# Altium schematic sheet [01] BP_LCD_Panel_v1.SchDoc("[01] BP_LCD_Panel_v1")
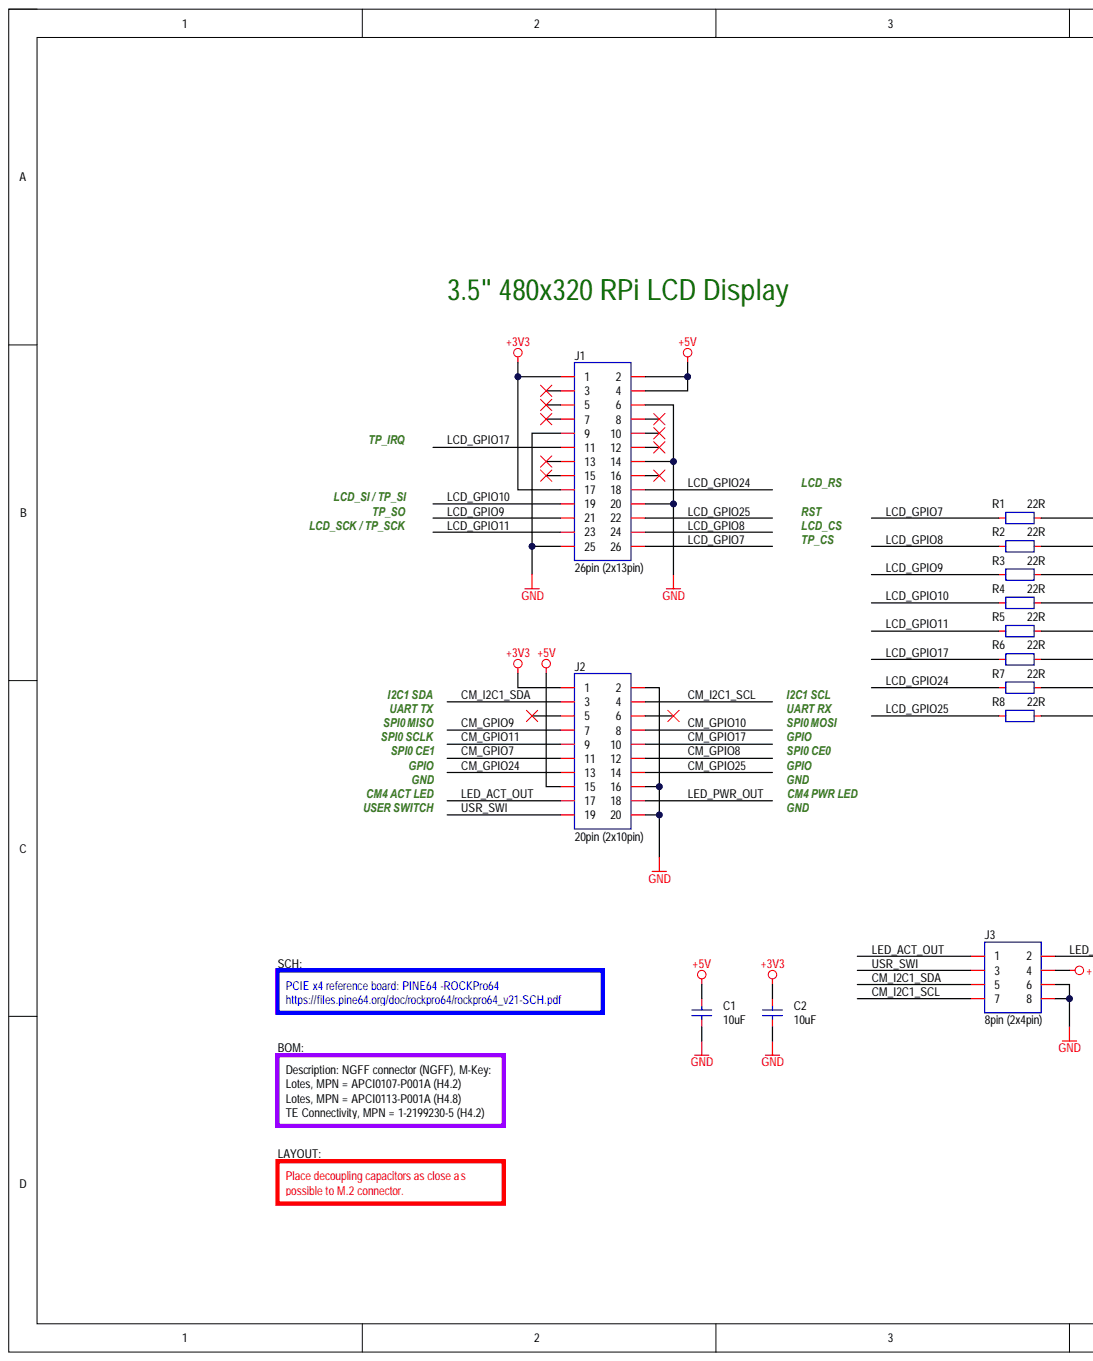
[diagram p0: [01] BP_LCD_Panel_v1.SchDoc, left]
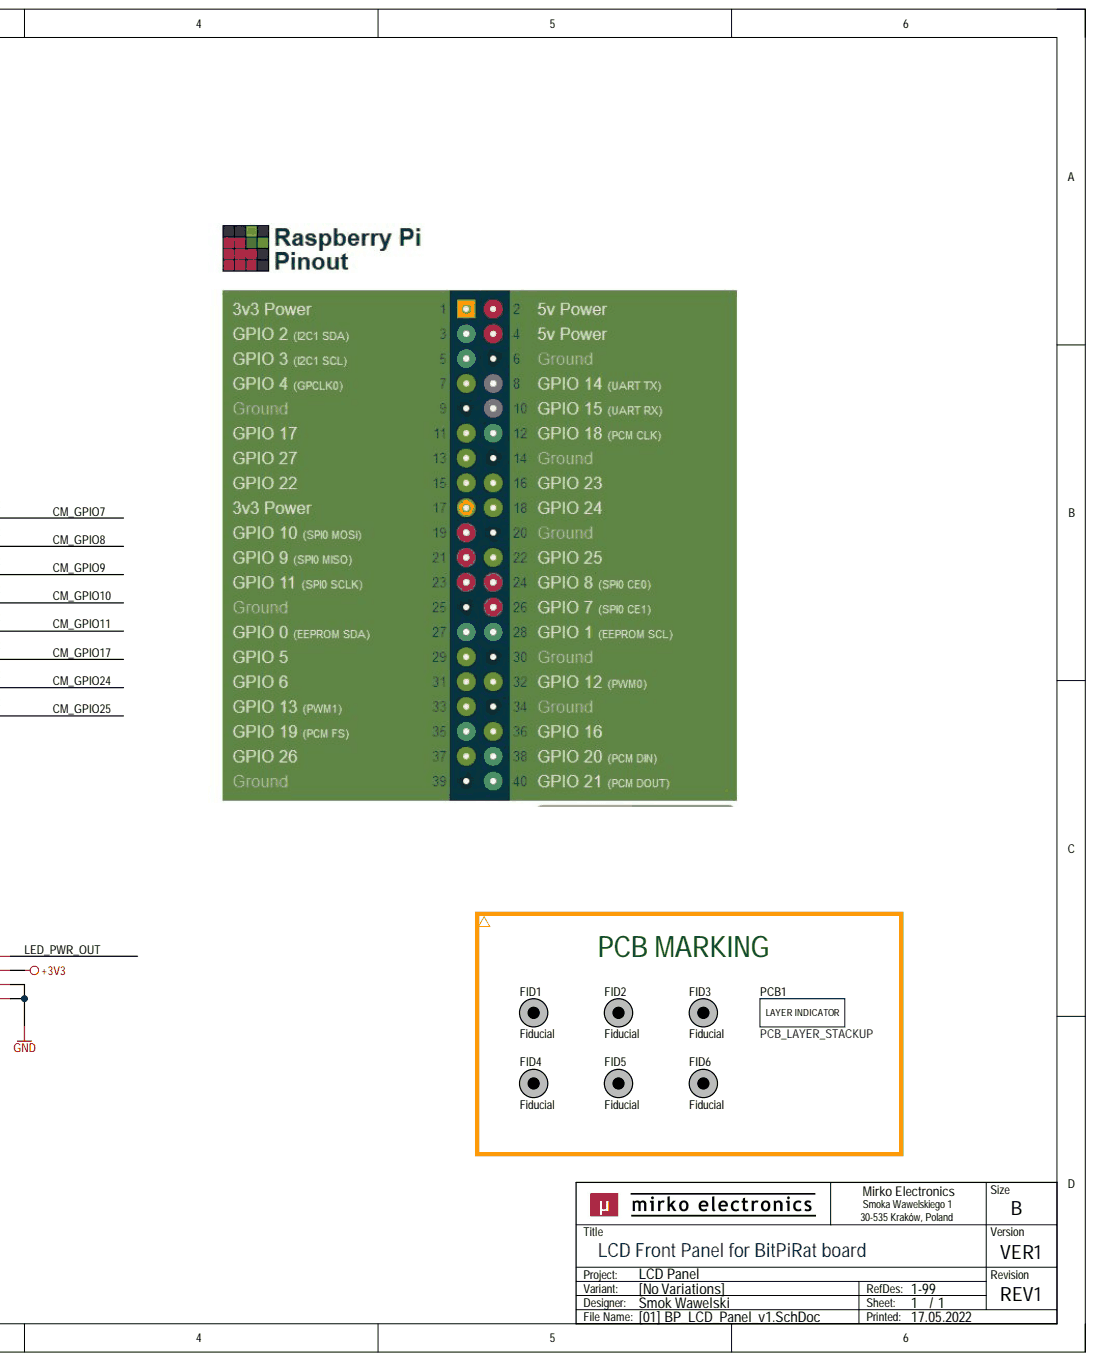
[diagram p1: [01] BP_LCD_Panel_v1.SchDoc, right]

## Parts
C1  10uF  [CAP_0603_R]
C2  10uF  [CAP_0603_R]
FID1  Fiducial  [PCB_FID_RND_100x300]  (no BOM)
FID2  Fiducial  [PCB_FID_RND_100x300]  (no BOM)
FID3  Fiducial  [PCB_FID_RND_100x300]  (no BOM)
FID4  Fiducial  [PCB_FID_RND_100x300]  (no BOM)
FID5  Fiducial  [PCB_FID_RND_100x300]  (no BOM)
FID6  Fiducial  [PCB_FID_RND_100x300]  (no BOM)
J1  26pin (2x13pin)  [CON_HDR_P254_2X13_STR_SMD_R]
J2  20pin (2x10pin)  [CON_IDC_SMD_P254_2X10]
J3  8pin (2x4pin)  [CON_IDC_SMD_P254_2X04]
PCB1  PCB_LAYER_STACKUP  [PCB_STACKUP-2L_A1]  (no BOM)
R1  22R  [RES_0603_R]
R2  22R  [RES_0603_R]
R3  22R  [RES_0603_R]
R4  22R  [RES_0603_R]
R5  22R  [RES_0603_R]
R6  22R  [RES_0603_R]
R7  22R  [RES_0603_R]
R8  22R  [RES_0603_R]

## Nets
+3V3: C2.2 J1.1 J1.17 J2.1 J3.4
+5V: C1.2 J1.2 J1.4 J2.15
GND: C1.1 C2.1 J1.6 J1.9 J1.14 J1.20 J1.25 J2.2 J2.16 J2.20 J3.6 J3.8
CM_GPIO7: J2.11 R1.2
CM_GPIO8: J2.12 R2.2
CM_GPIO9: J2.7 R3.2
CM_GPIO10: J2.8 R4.2
CM_GPIO11: J2.9 R5.2
CM_GPIO17: J2.10 R6.2
CM_GPIO24: J2.13 R7.2
CM_GPIO25: J2.14 R8.2
CM_I2C1_SCL: J2.4 J3.7
CM_I2C1_SDA: J2.3 J3.5
LCD_GPIO7: J1.26 R1.1
LCD_GPIO8: J1.24 R2.1
LCD_GPIO9: J1.21 R3.1
LCD_GPIO10: J1.19 R4.1
LCD_GPIO11: J1.23 R5.1
LCD_GPIO17: J1.11 R6.1
LCD_GPIO24: J1.18 R7.1
LCD_GPIO25: J1.22 R8.1
LED_ACT_OUT: J2.17 J3.1
LED_PWR_OUT: J2.18 J3.2
USR_SWI: J2.19 J3.3

Not connected: J1 3 5 7 8 10 12 13 15 16; J2 5 6

## Library data of the parts
C1 C2 = CAP-0023: Capacitor; MLCC; GENERIC; TBD; TBD; TBD; TBD; SMD; 0603 (1608);; Database Table Name: CAPACITOR$; Component Kind: Standard; Component Subtype: MLCC; Component Type: Capacitor; Manufacturer: GENERIC; Mounting Type: SMD; MPN: GENERIC; Package (Case): 0603 (1608); Supplier: ?; Value: 10uF; Library Name: MirFol_db_local.DbLib
FID1-FID6 = PCB_FID_RND: PCB Marking; Fiducial; Round;; Component Subtype: -; Component Type: Fiducial; Mounting Type: -; Supplier: ?; Value: Fiducial; Library Name: MirFol_lib.SchLib
J1 = CON_2X13_ROW: Connector; 2 Row;; Manufacturer: GENERIC; Component Subtype: Pin Header; Package (Case): THT; Component Kind: Standard; Component Type: Connector; Mounting Type: THT; MPN: GENERIC; Supplier: ?; Value: 26pin (2x13pin); Library Name: Pineberry_Lib.SchLib
J2 = CON_2X10_ROW: Connector; 2 Row;; Manufacturer: GENERIC; Component Subtype: Pin Header; Package (Case): THT; Component Kind: Standard; Component Type: Connector; Mounting Type: THT; MPN: GENERIC; Supplier: ?; Value: 20pin (2x10pin); Library Name: MirFol_lib.SchLib
J3 = CON_2X04_ROW: Connector; 2 Row;; Manufacturer: GENERIC; Component Subtype: Pin Header; Package (Case): THT; Component Kind: Standard; Component Type: Connector; Mounting Type: THT; MPN: GENERIC; Supplier: ?; Value: 8pin (2x4pin); Library Name: MirFol_lib.SchLib
R1-R8 = RES-0030: Resistor; Thick Film; 22R; 1%; 100mW; 100PPM; 75V; Standard; SMD; 0603 (1608);; Manufacturer: Yageo Corporation; MPN: TBD; Component Body: 1.60 x 0.80mm; Database Table Name: RESISTOR$; Packaging Type: Tape & Reel; Component Height: 0.45mm; Component Kind: Standard; Component Subtype: Thick Film; Component Type: Resistor; Features: Standard; ID: RES-0030; Mounting Type: SMD; Operating Temperature: -55°C to +155°C; Package (Case): 0603 (1608); Power Rating: 100mW; Resistance: 22R; ROHS Status: RoHS Compliant; TCR: 100PPM; Tolerance: 1%; Value: 22R; Voltage Rating: 75V; Library Name: MirFol_db_local.DbLib
PCB1 = PCB_LAYER_STACKUP: Component Subtype: -; Component Type: PCB Marking; Mounting Type: -; Supplier: ?; Library Name: MirFol_lib.SchLib
